# Altium schematic sheet GEN_4xPHASE_1.01.SchDoc(GEN_4xPHASE_1.01)
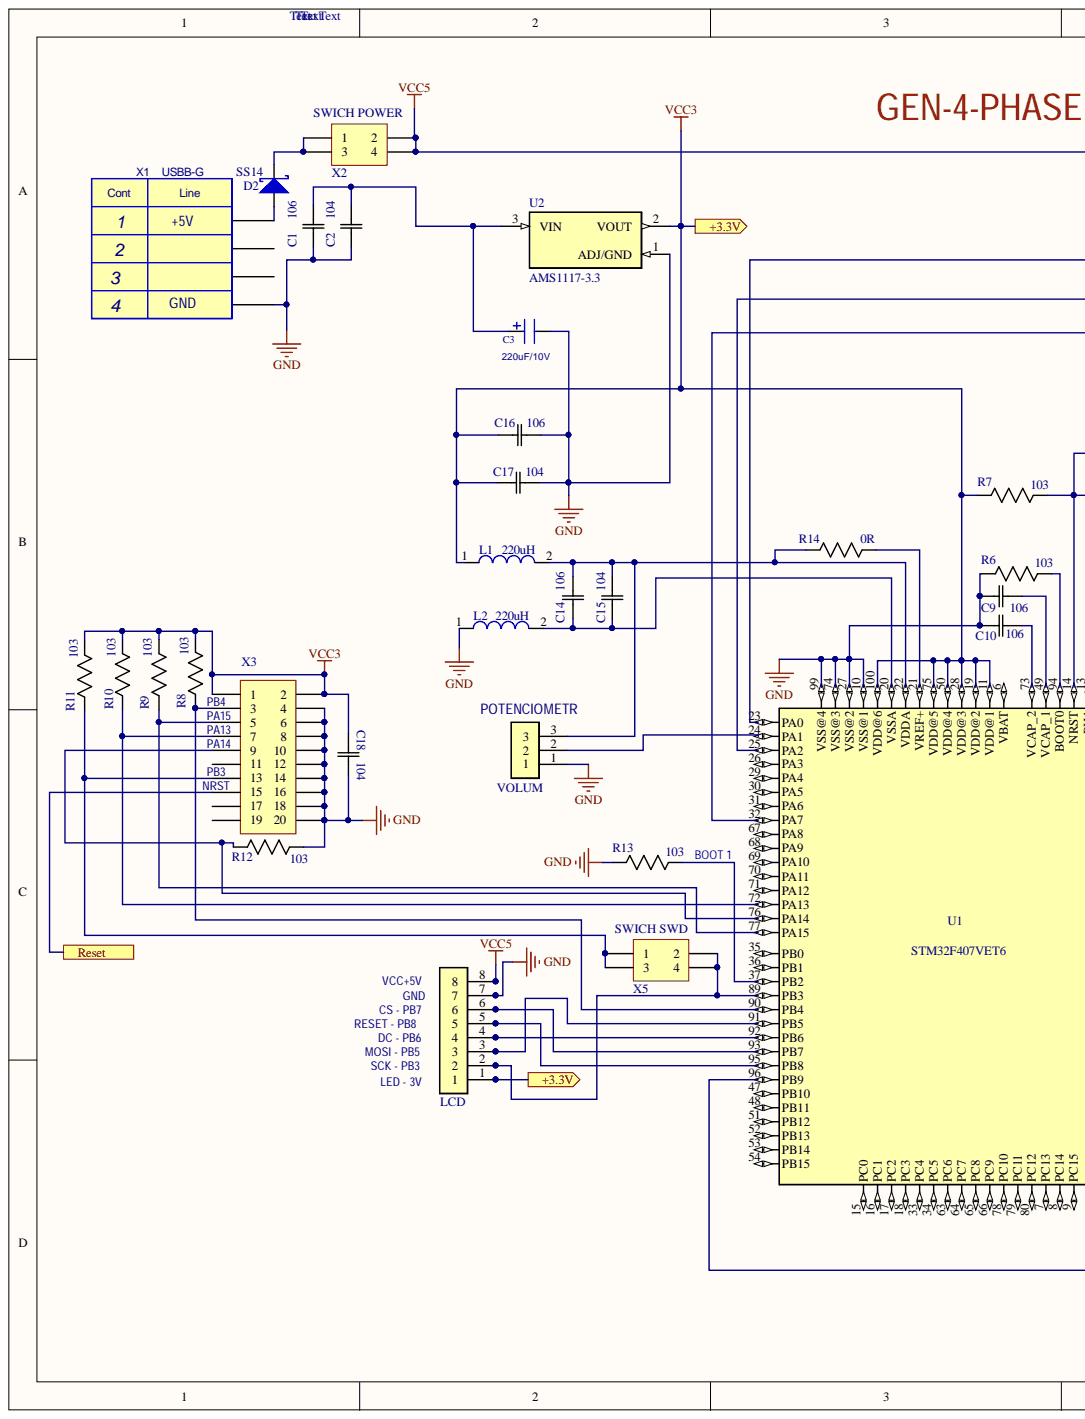
[diagram p0: GEN_4xPHASE_1.01.SchDoc, left]
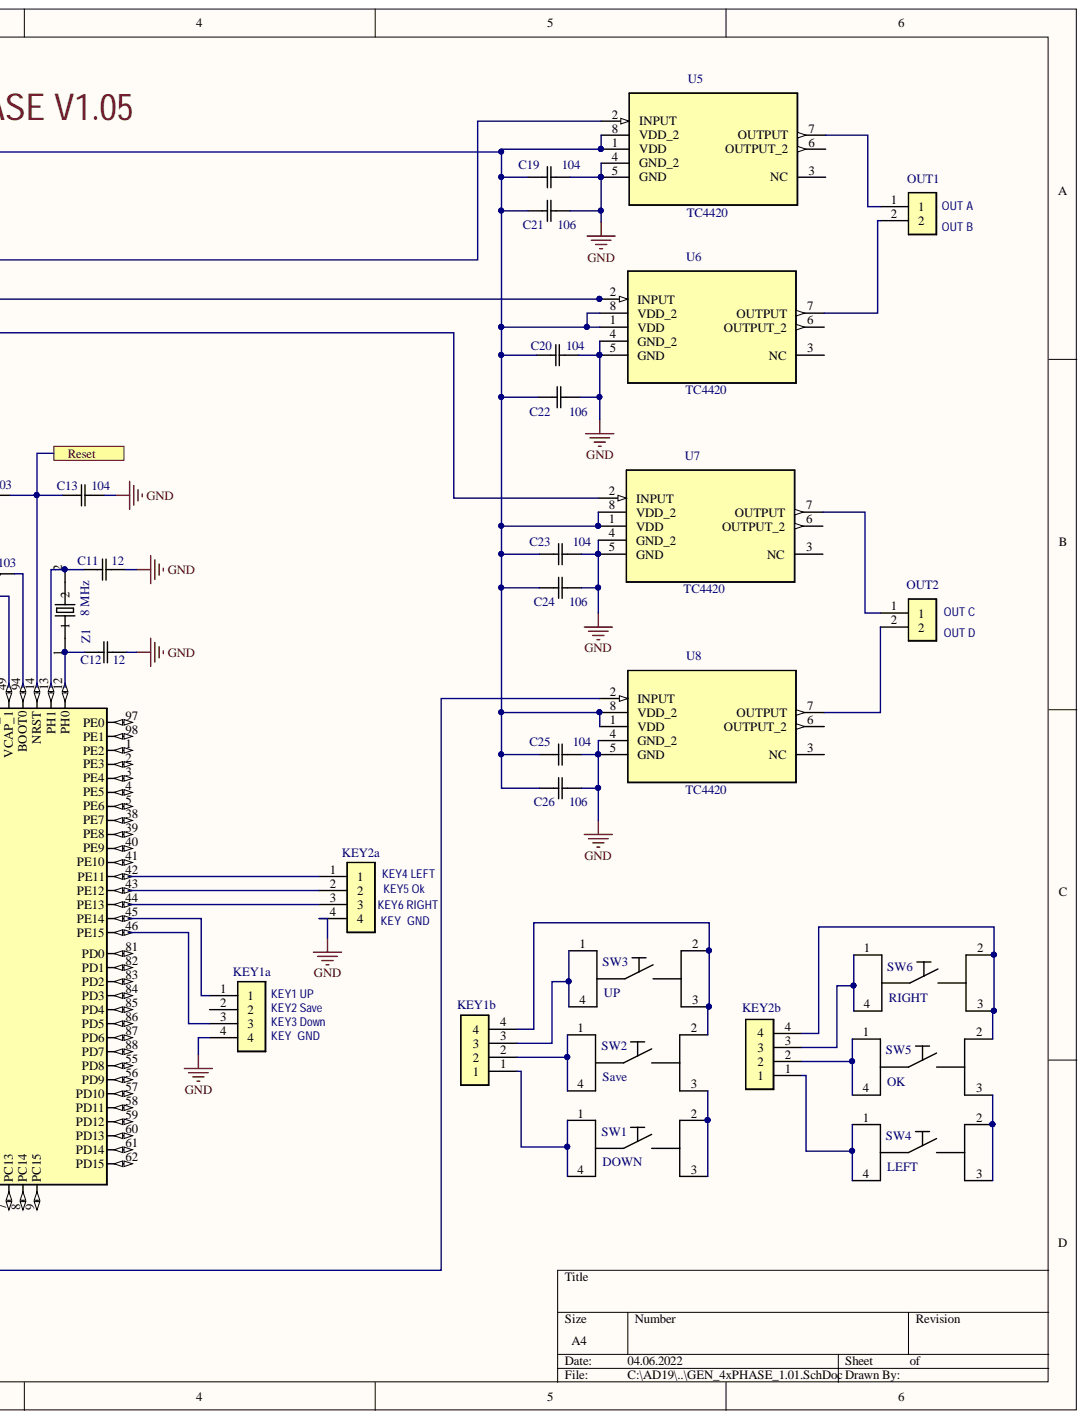
[diagram p1: GEN_4xPHASE_1.01.SchDoc, right]

## Parts
C1  106  [CAPC3216X94N]
C2  104  [CAPC3216X94N]
C3  220uF/10V  [Cap 0810]
C9  106  [CAPC3216X94N]
C10  106  [CAPC3216X94N]
C11  12  [CAPC3216X94N]
C12  12  [CAPC3216X94N]
C13  104  [CAPC3216X94N]
C14  106  [CAPC3216X94N]
C15  104  [CAPC3216X94N]
C16  106  [CAPC3216X94N]
C17  104  [CAPC3216X94N]
C18  104  [CAPC3216X94N]
C19  104  [CAPC3216X94N]
C20  104  [CAPC3216X94N]
C21  106  [CAPC3216X94N]
C22  106  [CAPC3216X94N]
C23  104  [CAPC3216X94N]
C24  106  [CAPC3216X94N]
C25  104  [CAPC3216X94N]
C26  106  [CAPC3216X94N]
D2  SS14  [DO-214AC]
KEY1a  282837-4  [TE_282837-4]  (value not drawn)
KEY1b  282837-4  [TE_282837-4]  (value not drawn)
KEY2a  282837-4  [TE_282837-4]  (value not drawn)
KEY2b  282837-4  [TE_282837-4]  (value not drawn)
L1  220uH  [INDP5858X480N]
L2  220uH  [INDP5858X480N]
LCD  282837-4  [TE_282837-4]  (value not drawn)
OUT1  282837-4  [TE_282837-4]  (value not drawn)
OUT2  282837-4  [TE_282837-4]  (value not drawn)
R6  103  [RESC3216X71N]
R7  103  [RESC3216X71N]
R8  103  [RESC3216X71N]
R9  103  [RESC3216X71N]
R10  103  [RESC3216X71N]
R11  103  [RESC3216X71N]
R12  103  [RESC3216X71N]
R13  103  [RESC3216X71N]
R14  0R  [RESC3216X71N]
SW1  DOWN  [SWITCH_1241.1607.23]
SW2  Save  [SWITCH_1241.1607.23]
SW3  UP  [SWITCH_1241.1607.23]
SW4  LEFT  [SWITCH_1241.1607.23]
SW5  OK  [SWITCH_1241.1607.23]
SW6  RIGHT  [SWITCH_1241.1607.23]
U1  STM32F407VET6  [LQFP100-0.5-13.8X13.8MM]
U2  AMS1117-3.3  [SOT229P700X180-4N]
U5  TC4420  [SOIC127P600X175-8N]
U6  TC4420  [SOIC127P600X175-8N]
U7  TC4420  [SOIC127P600X175-8N]
U8  TC4420  [SOIC127P600X175-8N]
VOLUM  282837-4  [TE_282837-4]  (value not drawn)
X1  USBB-G  [USBB-G]
X2  SWICH POWER  [PLD-4-2]
X3  PLD2-20  [PLD-20-2]  (value not drawn)
X5  SWICH SWD  [PLD-4-2]
Z1  8 MHz  [XTAL1170X500X450]

## Ports
+3.3V, Reset

## Nets
GND: C1.1 C2.1 C3.2 C9.1 C10.1 C11.2 C12.2 C13.2 C16.2 C17.2 C18.2 C19.2 C20.2 C21.2 C22.2 C23.2 C24.2 C25.2 C26.2 KEY1a.4 KEY2a.4 L2.1 LCD.7 R6.2 R12.1 R13.2 U1.10(VSS@1) U1.27(VSS@2) U1.74(VSS@3) U1.99(VSS@4) U2.1 U5.4(GND_2) U5.5(GND) U6.4(GND_2) U6.5(GND) U7.4(GND_2) U7.5(GND) U8.4(GND_2) U8.5(GND) VOLUM.1 X1.4 X3.4 X3.6 X3.8 X3.10 X3.12 X3.14 X3.16 X3.18 X3.20
VCC5: C19.1 C20.1 C21.1 C22.1 C23.1 C24.1 C25.1 C26.1 LCD.8 U5.1(VDD) U5.8(VDD_2) U6.1(VDD) U6.8(VDD_2) U7.1(VDD) U7.8(VDD_2) U8.1(VDD) U8.8(VDD_2) X2.2 X2.4
Reset: C13.1 R7.1 U1.14(NRST) X3.15 ; port Reset
VCC3: C16.1 C17.1 C18.1 L1.1 LCD.1 R7.2 R8.1 R9.1 R10.1 R11.1 U1.11(VDD@1) U1.19(VDD@2) U1.28(VDD@3) U1.50(VDD@4) U1.75(VDD@5) U1.100(VDD@6) U2.2 X3.1 X3.2 ; port +3.3V
NetC1_2: C1.2 C2.2 C3.1 U2.3
NetC9_2: C9.2 U1.49(VCAP_1)
NetC10_2: C10.2 U1.73(VCAP_2)
NetC11_1: C11.1 U1.13(PH1) Z1.2
NetC12_1: C12.1 U1.12(PH0) Z1.1
NetC14_1: C14.1 C15.1 L2.2 U1.20(VSSA)
NetC14_2: C14.2 C15.2 L1.2 R14.2 U1.22(VDDA) VOLUM.3
NetD2_1: D2.1 X1.1
NetD2_2: D2.2 X2.1 X2.3
NetKEY1a_1: KEY1a.1 U1.45(PE14)
NetKEY1a_3: KEY1a.3 U1.46(PE15)
NetKEY1b_1: KEY1b.1 SW1.1 SW1.4
NetKEY1b_2: KEY1b.2 SW2.1 SW2.4
NetKEY1b_3: KEY1b.3 SW3.1 SW3.4
NetKEY1b_4: KEY1b.4 SW2.2 SW3.2 SW3.3
NetKEY2a_1: KEY2a.1 U1.42(PE11)
NetKEY2a_2: KEY2a.2 U1.43(PE12)
NetKEY2a_3: KEY2a.3 U1.44(PE13)
NetKEY2b_1: KEY2b.1 SW4.1 SW4.4
NetKEY2b_2: KEY2b.2 SW5.1 SW5.4
NetKEY2b_3: KEY2b.3 SW6.1 SW6.4
NetKEY2b_4: KEY2b.4 SW5.2 SW6.2 SW6.3
NetLCD_2: LCD.2 U1.89(PB3) X5.2 X5.4
NetLCD_3: LCD.3 U1.91(PB5)
NetLCD_4: LCD.4 U1.92(PB6)
NetLCD_5: LCD.5 U1.95(PB8)
NetLCD_6: LCD.6 U1.93(PB7)
NetOUT1_1: OUT1.1 U5.7(OUTPUT)
NetOUT1_2: OUT1.2 U6.7(OUTPUT)
NetOUT2_1: OUT2.1 U7.7(OUTPUT)
NetOUT2_2: OUT2.2 U8.7(OUTPUT)
NetR6_1: R6.1 U1.94(BOOT0)
NetR8_2: R8.2 U1.90(PB4) X3.3
NetR9_2: R9.2 U1.77(PA15) X3.5
NetR10_2: R10.2 U1.72(PA13) X3.7
NetR11_2: R11.2 X3.13 X5.1 X5.3
NetR12_2: R12.2 U1.76(PA14) X3.9
NetR13_1: R13.1 U1.37(PB2)
NetR14_1: R14.1 U1.21(VREF+)
NetSW1_2: SW1.2 SW1.3 SW2.3
NetSW4_2: SW4.2 SW4.3 SW5.3
NetU1_23: U1.23(PA0) U5.2(INPUT)
NetU1_24: U1.24(PA1) VOLUM.2
NetU1_25: U1.25(PA2) U6.2(INPUT)
NetU1_32: U1.32(PA7) U7.2(INPUT)
NetU1_96: U1.96(PB9) U8.2(INPUT)

Not connected: KEY1a 2; U1 1(PE2) 2(PE3) 3(PE4) 4(PE5) 5(PE6) 6(VBAT) 7(PC13) 8(PC14) 9(PC15) 15(PC0) 16(PC1) 17(PC2) 18(PC3) 26(PA3) 29(PA4) 30(PA5) 31(PA6) 33(PC4) 34(PC5) 35(PB0) 36(PB1) 38(PE7) 39(PE8) 40(PE9) 41(PE10) 47(PB10) 48(PB11) 51(PB12) 52(PB13) 53(PB14) 54(PB15) 55(PD8) 56(PD9) 57(PD10) 58(PD11) 59(PD12) 60(PD13) 61(PD14) 62(PD15) 63(PC6) 64(PC7) 65(PC8) 66(PC9) 67(PA8) 68(PA9) 69(PA10) 70(PA11) 71(PA12) 78(PC10) 79(PC11) 80(PC12) 81(PD0) 82(PD1) 83(PD2) 84(PD3) 85(PD4) 86(PD5) 87(PD6) 88(PD7) 97(PE0) 98(PE1); U5 3(NC) 6(OUTPUT_2); U6 3(NC) 6(OUTPUT_2); U7 3(NC) 6(OUTPUT_2); U8 3(NC) 6(OUTPUT_2); X1 2 3; X3 11 17 19

## Library data of the parts
C1 C2 C9-C26 = 12061C103KAT4A: 1206 10nF 100 V В± 10% Р”РѕРїСѓСЃРє X7R SMT РњРЅРѕРіРѕСЃР»РѕР№РЅС‹Р№ РєРµСЂР°РјРёС‡РµСЃРєРёР№ РєРѕРЅРґРµРЅСЃР°С‚РѕСЂ; MF: AVX; SnapEDA_Link: https://www.snapeda.com/parts/12061C103KAT4A/AVX/view-part/?ref=snap; Price: 0.05 USD; Package: 1206 AVX; MP: 12061C103KAT4A; Library Name: C12061.IntLib; Component Kind: Standard; Availability: Bad
C3 = CAP0810Alu: Capacitor; Value: 220uF/10V; Library Name: AX_Altium_v2.3.IntLib; Component Kind: Standard
D2 = DS_DO-214: Schottky Diode; Library Name: AX_Altium_v2.3.IntLib; Component Kind: Standard
KEY1a KEY1b KEY2a KEY2b LCD OUT1 OUT2 VOLUM = 282837-4: TERMI-BLOK PCB MOUNT 4P; MF: TE Connectivity; SnapEDA_Link: https://www.snapeda.com/parts/282837-4/TE%20Connectivity%20AMP%20Connectors/view-part/?ref=snap; Price: None; Package: None; MP: 282837-4; Link: http://www.te.com/usa-en/product-282837-4.html; EU_RoHS_Compliance: Compliant with Exemptions; Component Kind: Standard; Availability: Unavailable
  KEY1a KEY1b KEY2a KEY2b: Library Name: PIN-4.IntLib
  LCD: Library Name: PIN-8.IntLib
  OUT1 OUT2: Library Name: PIN-02.IntLib
  VOLUM: Library Name: PIN-03.IntLib
L1 L2 = SDR0604-221KL: РњРѕС‰РЅРѕСЃС‚СЊ РёРЅРґСѓРєС‚РѕСЂР° Wirewound 220uH 10% 1KHz 20Q-С„Р°РєС‚РѕСЂ Р¤РµСЂСЂРёС‚РѕРІС‹Р№ 350mA 1.57Ohm DCR T / R; MF: Bourns; SnapEDA_Link: https://www.snapeda.com/parts/SDR0604-221KL/Bourns/view-part/?ref=snap; Price: 0.27 USD; Package: Nonstandard Bourns; MP: SDR0604-221KL; Library Name: 220uH-SDR0604.IntLib; Component Kind: Standard; Availability: Good
R6-R13 = RC1206JR-07470RL: Res РўРѕР»СЃС‚Р°СЏ РїР»РµРЅРєР° 3,2 x 1,6 РјРј 470 РћРј 5% 0,25 Р’С‚ _1/4 Р’С‚_ 100 ppm / C Р›РёС‚Р°СЏ SMD-Р±СѓРјР°РіР° T / R; MF: Yageo; SnapEDA_Link: https://www.snapeda.com/parts/RC1206JR-07470RL/Yageo/view-part/?ref=snap; Price: 0.02 USD; Package: 1206 Yageo; MP: RC1206JR-07470RL; Library Name: R1206.IntLib; Component Kind: Standard; Availability: Good
R14 = Res 1206: Res 1206 3,2 x 1,6 SMD; MP: RC1206JR-07470RL; MF: Yageo; Package: 1206 Yageo; Component Kind: Standard; Availability: Good; Library Name: VEB_NL_5.09.SCHLIB
SW1-SW6 = 1241.1607.23: Switch Tactile NO SPST РљСЂСѓРіР»Р°СЏ РєРЅРѕРїРєР° J-Lead 0.05A 30VAC 42VDC 1000000Cycles 1.8N SMD T / R; MF: Schurter; SnapEDA_Link: https://www.snapeda.com/parts/1241.1607.23/Schurter/view-part/?ref=snap; Price: 1.96 USD; Package: None; MP: 1241.1607.23; Component Kind: Standard; Availability: Good; Library Name: BUT_12x12.IntLib
U1 = MCU-STM32F407VET6(LQFP100): STM32 Series 32-bit 512 kB Flash 192 kB RAM РњРёРєСЂРѕРєРѕРЅС‚СЂРѕР»Р»РµСЂ РЅР° Р±Р°Р·Рµ ARM - LQFP-100; MF: STMicroelectronics; SnapEDA_Link: https://www.snapeda.com/parts/STM32F407VET6/STMicroelectronics/view-part/?ref=snap; Price: 9.04 USD; Package: LQFP-100 STMicroelectronics; MP: STM32F407VET6; Library Name: STM32F407VET6.IntLib; Component Kind: Standard; Availability: Good
U2 = AMS1117-3.3: MF: NEC; SnapEDA_Link: https://www.snapeda.com/parts/AMS1117-3.3/NEC/view-part/?ref=snap; Price: None; Package: None; MP: AMS1117-3.3; Library Name: AMS1117-3.3.IntLib; Component Kind: Standard; Availability: Unavailable
U5-U8 = TC4420EOA: TC4420 Series 6 A 18 Vmax 2,5 РћРј СЃ РЅРёР·РєРёРј СЃРѕРїСЂРѕС‚РёРІР»РµРЅРёРµРј Р±РµР· РёРЅРІРµСЂС‚РѕСЂР° MOSFET - SOIC-8; MF: Microchip; SnapEDA_Link: https://www.snapeda.com/parts/TC4420EOA/Microchip/view-part/?ref=snap; Price: 1.15 USD; Package: SOIC-8 Microchip; MP: TC4420EOA; Library Name: TC4420EOA.IntLib; Component Kind: Standard; Availability: Good
X2 X5 = PLD2-4: Header, 2-Pin, Dual row; Library Name: AX_Altium_v2.3.IntLib; LatestRevisionNote: Re-released for DXP Platform.; LatestRevisionDate: 17-Jul-2002; Component Kind: Standard; Publisher: Altium Limited
X3 = PLD2-20: Header, 10-Pin, Dual row; Library Name: AX_Altium_v2.3.IntLib; LatestRevisionNote: Re-released for DXP Platform.; LatestRevisionDate: 17-Jul-2002; Component Kind: Standard; Publisher: Altium Limited
Z1 = FOXSDLF/080-20: Crystal 8MHz? 30ppm _Tol_? 50ppm _СЃС‚Р°Р±РёР»СЊРЅРѕСЃС‚СЊ_ 20pF Р¤РћРќР” 80Ohm 2-РєРѕРЅС‚Р°РєС‚РЅС‹Р№ HC-49 / SDLF SMD T / R; MF: Fox Electronics; SnapEDA_Link: https://www.snapeda.com/parts/FOXSDLF/080-20/Fox%2520Electronics/view-part/?ref=snap; Price: 0.23 USD; Package: HC49SD Fox Electronics; MP: FOXSDLF/080-20; Library Name: 8MHZ-HC49SD.IntLib; Component Kind: Standard; Availability: Good
X1 = USBB-G: Library Name: USB-B-G.IntLib; Description: USBB-G; Component Kind: Standard
